# Altium schematic sheet MFDD_SM-1420.SchDoc
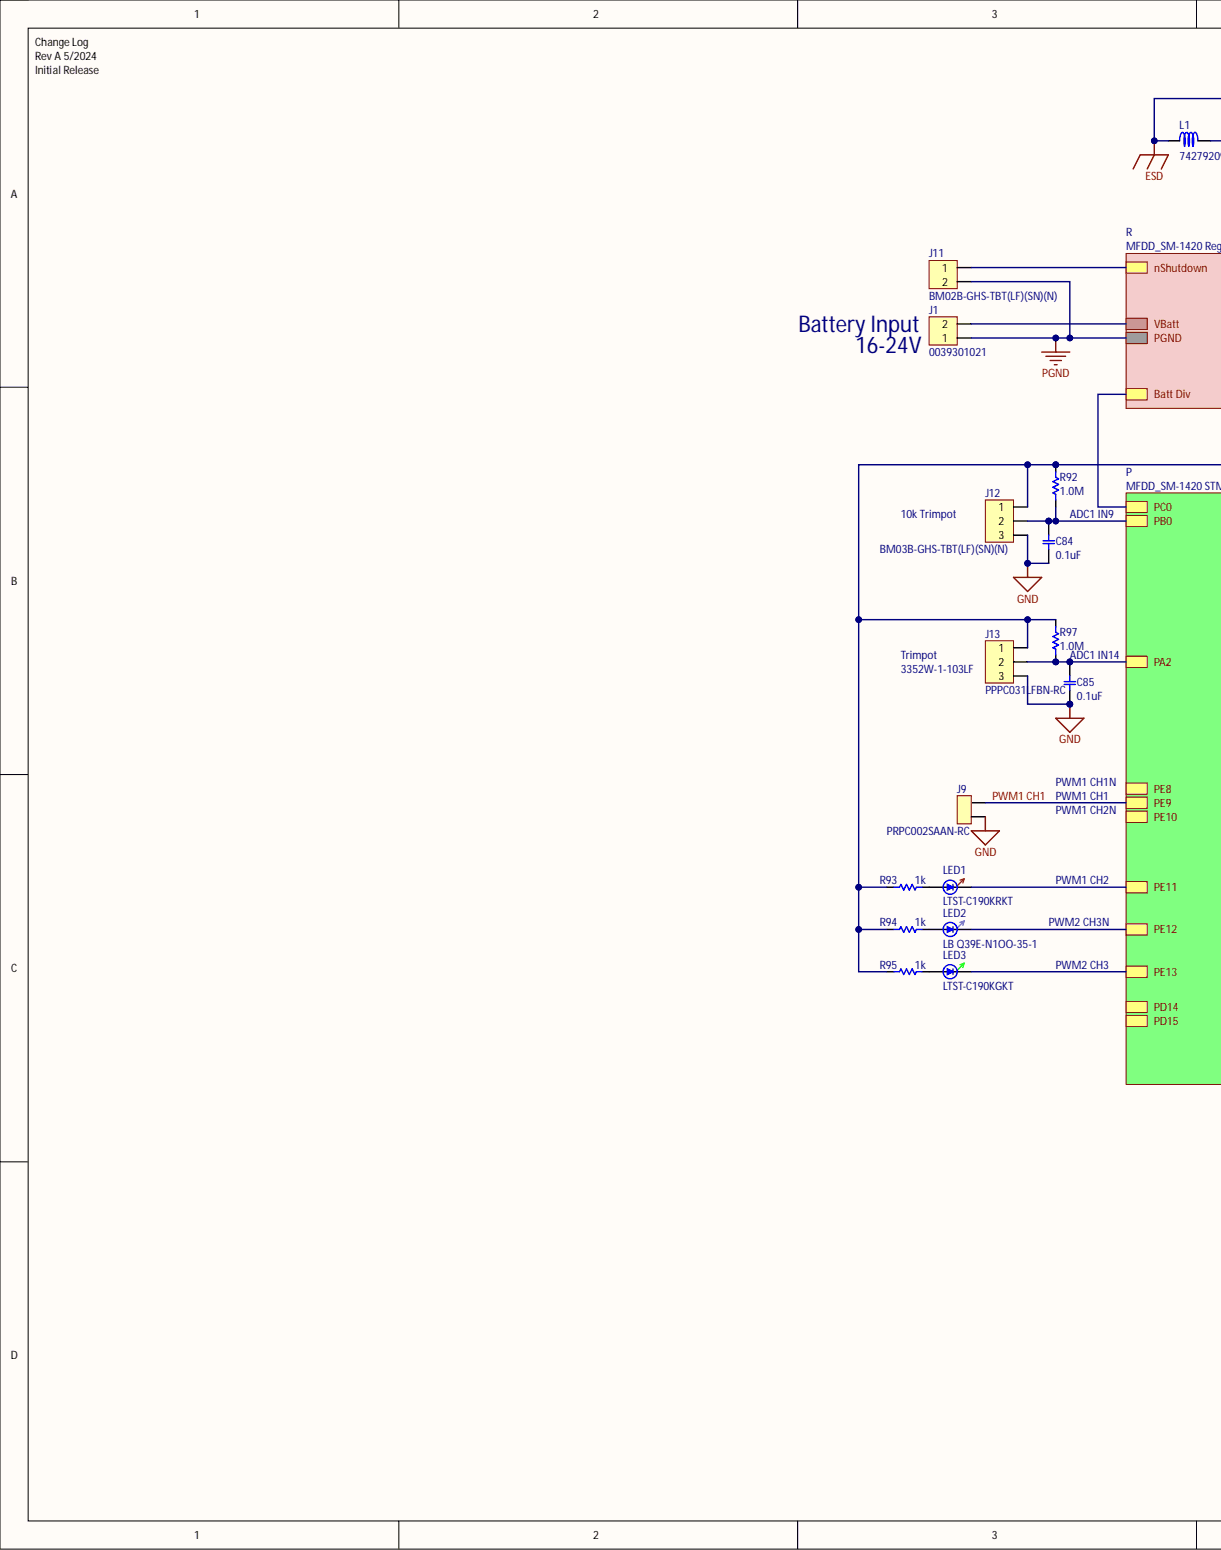
[diagram p0: MFDD_SM-1420.SchDoc, left]
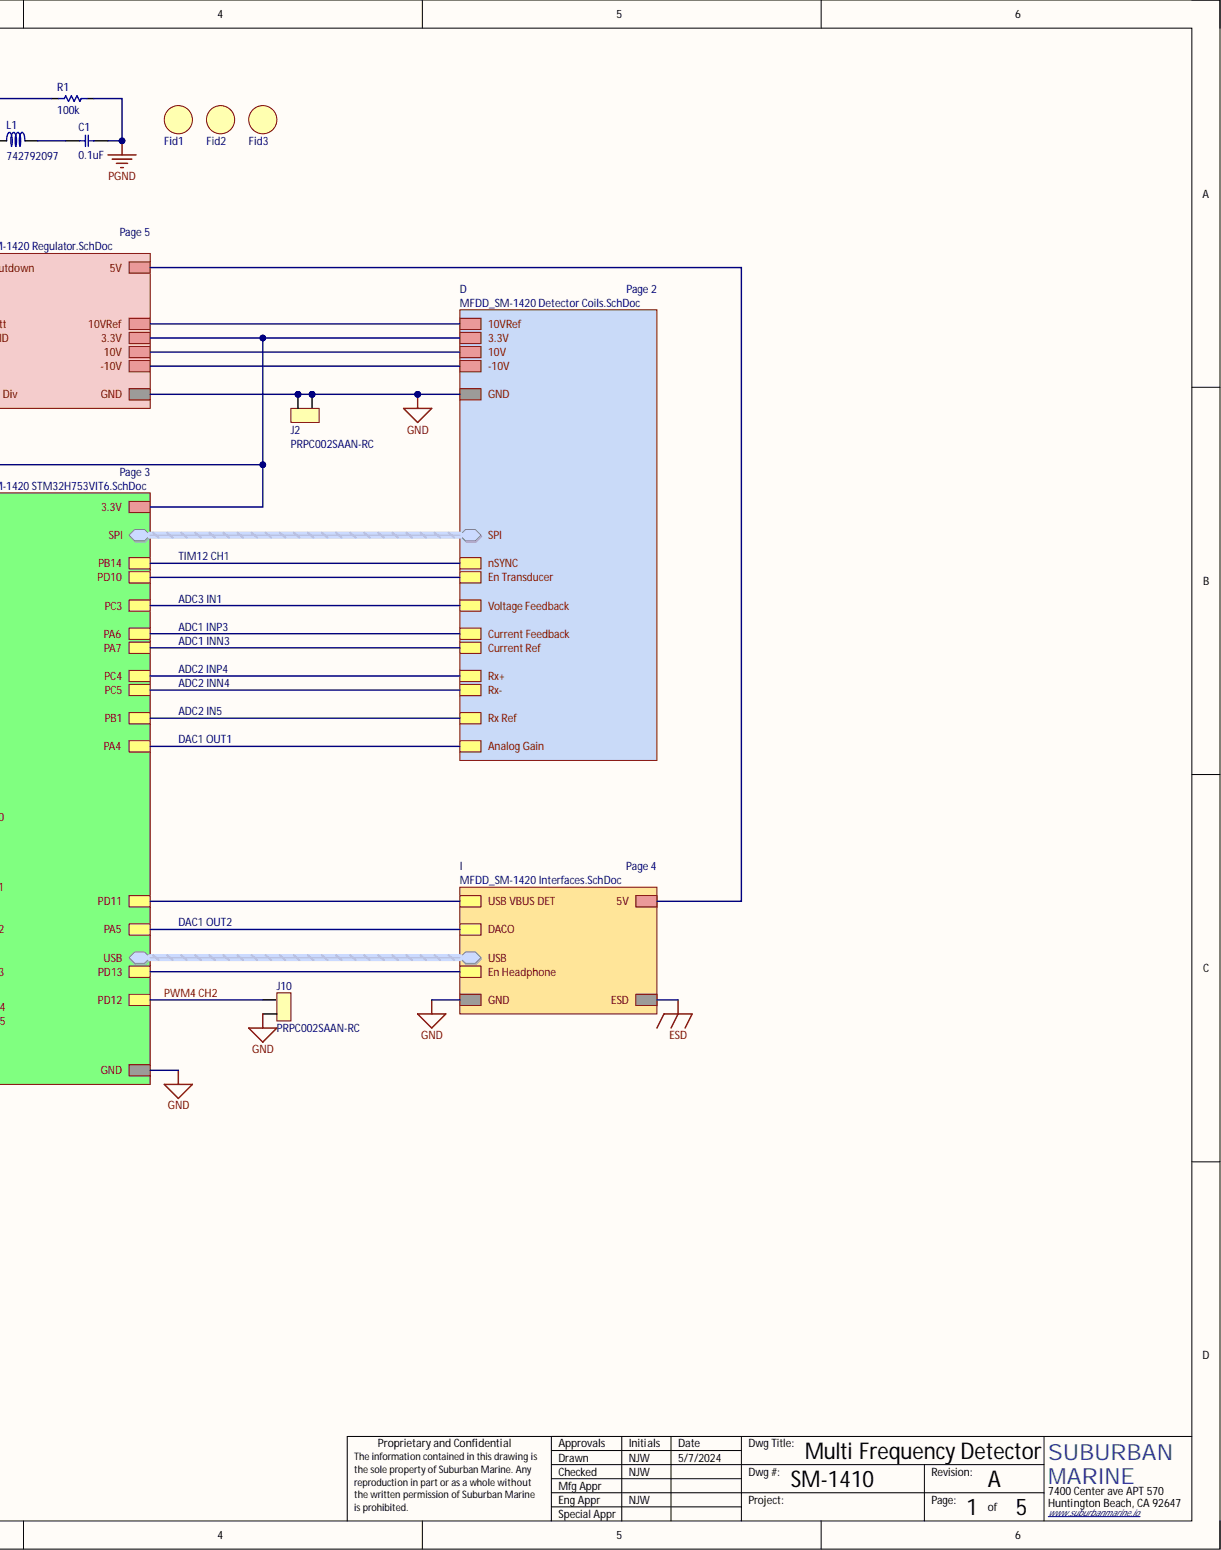
[diagram p1: MFDD_SM-1420.SchDoc, right]

## Parts
C1  value 0.1uF  [1206_C]  (hidden comment: C3216X7R2E104K160AA)
C84  value 0.1uF  [0603_C]  (hidden comment: GCJ188R71H104KA12D)
C85  value 0.1uF  [0603_C]  (hidden comment: GCJ188R71H104KA12D)
Fid1  Fiducal?  [Fiducal]  (value not drawn)  (no BOM)
Fid2  Fiducal?  [Fiducal]  (value not drawn)  (no BOM)
Fid3  Fiducal?  [Fiducal]  (value not drawn)  (no BOM)
J1  0039301021  [0039301021]
J2  PRPC002SAAN-RC  [HDR1X2]  (no BOM)
J9  PRPC002SAAN-RC  [HDR1X2]  (no BOM)
J10  PRPC002SAAN-RC  [HDR1X2]  (no BOM)
J11  BM02B-GHS-TBT(LF)(SN)(N)  [BM02B-GHS-TBT(LF)(SN)(N)]
J12  BM03B-GHS-TBT(LF)(SN)(N)  [BM03B-GHS-TBT(LF)(SN)(N)]
J13  PPPC031LFBN-RC  [PPPC031LFBN-RC]
L1  742792097  [0805]
LED1  LTST-C190KRKT  [0603 Diode]
LED2  LB Q39E-N1OO-35-1  [0603 Diode]
LED3  LTST-C190KGKT  [0603 Diode]
R1  value 100k  [1206_R]  (hidden comment: ERJ-8GEYJ104V)
R92  value 1.0M  [0603_R]  (hidden comment: ERJ-3EKF1004V)
R93  value 1k  [0603_R]  (hidden comment: ERJ-3EKF1001V)
R94  value 1k  [0603_R]  (hidden comment: ERJ-3EKF1001V)
R95  value 1k  [0603_R]  (hidden comment: ERJ-3EKF1001V)
R97  value 1.0M  [0603_R]  (hidden comment: ERJ-3EKF1004V)

## Nets
ESD: L1.2 R1.1
GND: C84.1 C85.1 J2.1 J2.2 J9.2 J10.2 J12.3 J13.3
PGND: C1.2 J1.1 J11.2 R1.2
PWM1 CH1: J9.1
PWM4 CH2: J10.1
NetC1_1: C1.1 L1.1
NetC84_2: C84.2 J12.2 R92.1
NetC85_2: C85.2 J13.2 R97.1
NetJ1_2: J1.2
NetJ11_1: J11.1
NetJ12_1: J12.1 J13.1 R92.2 R93.2 R94.2 R95.2 R97.2
NetLED1_1: LED1.1 R93.1
NetLED1_2: LED1.2
NetLED2_1: LED2.1 R94.1
NetLED2_2: LED2.2
NetLED3_1: LED3.1 R95.1
NetLED3_2: LED3.2
5V:
10V:
10VRef:
-10V:
Analog Gain:
Batt Div:
Current Feedback:
Current Ref:
DACO:
En Headphone:
En Transducer:
nSYNC:
PB1:
PC3:
PC4:
PC5:
PD11:
PD14:
PD15:
PE8:
PE10:
SPI.CLK:
SPI.MISO:
SPI.MOSI:
SPI.nCS:
USB.DM:
USB.DP:
USB.VBUS:

## Library data of the parts
C1 = 0.1uF 250V 1206: CAP CER 0.1UF 250V X7R 1206; Manufacturer: TDK Corporation; Installation: SMD/SMT; Lead: 0; Tolerance: 10%; Vendor: Digikey; Vendor #: 445-2287-1-ND; Component Kind: Standard; Library Name: Capacitor.SchLib; Manufacturer #: C3216X7R2E104K160AA; Price: 0.19; Temperature: -55 to 125 C
C84 C85 = 0.1uF 50V 0603: CAP CER 0.1UF 50V X7R 0603; Manufacturer: Murata Electronics North America; Installation: SMD/SMT; Lead: 0; Tolerance: 10%; Vendor: Digikey; Vendor #: 490-5798-1-ND; Component Kind: Standard; Library Name: Capacitor.SchLib; Manufacturer #: GCJ188R71H104KA12D; PCB3D: Capacitor_0603; Price: 0.31; Temperature: -55 to 125 C
Fid1-Fid3 = Fiducal: Fiducal 40 mil; Library Name: Misc Components.SchLib
J1 = 0039301021: CONN HEADER 2POS 4.2MM R/A TIN; Manufacturer: Molex; Installation: Thru-hole; Lead: 0; Vendor: Digikey; Vendor #: WM1363-ND; Component Kind: Standard; Library Name: Connectors.SchLib; Manufacturer #: 0039301021; Price: 0.81; Temperature: -40 to 105 C; Value: 2 pos
J2 J9 J10 = Header 1x2: CONN HEADER .100" SNGL STR 2POS; Manufacturer: Sullins Connector Solutions; Installation: Thru-hole; Lead: 0; Vendor: Digikey; Vendor #: S1011EC-02-ND; Library Name: Headers.SchLib; Manufacturer #: PRPC002SAAN-RC; Price: 0.08; Temperature: -40 to 105 C; Value: 2 pos
J11 = BM02B-GHS-TBT(LF)(SN)(N): CONN HEADER SMD 2POS 1.25MM; Manufacturer: JST; Installation: SMD/SMT; Lead: 0; Vendor: Digikey; Vendor #: 455-1578-1-ND; Component Kind: Standard; Library Name: Connectors.SchLib; Manufacturer #: BM02B-GHS-TBT(LF)(SN)(N); Price: 0.43; Temperature: -25 to 85 C; Value: 2 pos
J12 = BM03B-GHS-TBT(LF)(SN)(N): CONN HEADER SMD 3POS 1.25MM; Manufacturer: JST; Installation: SMD/SMT; Lead: 0; Vendor: Digikey; Vendor #: 455-1579-1-ND; Component Kind: Standard; Library Name: Connectors.SchLib; Manufacturer #: BM03B-GHS-TBT(LF)(SN)(N); Price: 0.48; Temperature: -25 to 85 C; Value: 3 pos
J13 = PPPC031LFBN-RC: CONN HEADER FEMALE 3POS .1" GOLD; Manufacturer: Sullins Connector Solutions; Installation: Thru-hole; Vendor: Digikey; Vendor #: S7036-ND; Component Kind: Standard; Library Name: Headers.SchLib; Manufacturer #: PPPC031LFBN-RC; Price: 0.49; Temperature: -40 to 85 C; Value: 3 pos
L1 = 742792097: FERRITE BEAD 1.5 KOHM 0805 1LN; Manufacturer: Wurth Electronics Inc.; Installation: SMD/SMT; Lead: 0; Tolerance: 1A; Value: 1.5 kOhm @ 100MHz; Vendor: Digikey; Vendor #: 732-4649-1-ND; Component Kind: Standard; Library Name: Inductor.SchLib; Manufacturer #: 742792097; Price: 0.22; Temperature: -40 to 125 C
LED1 = LTST-C190KRKT: LED RED CLEAR 0603 SMD; Manufacturer: Lite-On Inc.; Installation: SMD/SMT; Lead: 0; Vendor: Digikey; Vendor #: 160-1436-1-ND; Component Kind: Standard; Library Name: Diode.SchLib; Manufacturer #: LTST-C190KRKT; Price: 0.27; Temperature: -30 to 85 C; Value: Red
LED2 = LB Q39E-N1OO-35-1: LED BLUE DIFFUSED 0603 SMD; Manufacturer: OSRAM Opto Semiconductors Inc.; Installation: SMD/SMT; Lead: 0; Vendor: Digikey; Vendor #: 475-2815-1-ND; Component Kind: Standard; Library Name: Diode.SchLib; Manufacturer #: LB Q39E-N1OO-35-1; Price: 0.43; Temperature: -30 to 85 C; Value: Blue
LED3 = LTST-C190KGKT: LED GREEN CLEAR 0603 SMD; Manufacturer: Lite-On Inc; Installation: SMD/SMT; Lead: 0; Vendor: Digikey; Vendor #: 160-1435-1-ND; Component Kind: Standard; Library Name: Diode.SchLib; Manufacturer #: LTST-C190KGKT; Price: 0.52; Temperature: -55 to 85 C; Value: Green
R1 = 100k 1206: RES 100K OHM 1/4W 5% 1206 SMD; Manufacturer: Panasonic Electronic Components; Installation: SMD/SMT; Lead: 0; Tolerance: 1%; Vendor: Digikey; Vendor #: P100KECT-ND; Component Kind: Standard; Library Name: Resistor.SchLib; Manufacturer #: ERJ-8GEYJ104V; Price: 0.1; Temperature: -55 to 155 C
R92 R97 = 1.0M 0603: RES SMD 1M OHM 1% 1/10W 0603 AEC-Q200; Manufacturer: Panasonic Electronic Components; Installation: SMD/SMT; Lead: 0; Vendor: Digikey; Vendor #: P1.00MHCT-ND; Component Kind: Standard; Library Name: Resistor.SchLib; Manufacturer #: ERJ-3EKF1004V; Price: 0.1; Temperature: -55 to 155 C; Tolerance: 1%
R93-R95 = 1k 0603: RES SMD 1K OHM 1% 1/10W 0603 AEC-Q200; Manufacturer: Panasonic Electronic Components; Installation: SMD/SMT; Lead: 0; Vendor: Digikey; Vendor #: P1.00KHDKR-ND; Component Kind: Standard; Library Name: Resistor.SchLib; Manufacturer #: ERJ-3EKF1001V; Price: 0.01; Temperature: -55 to 155 C; Tolerance: 1%
